# Altium schematic sheet impee-temp-book.SchDoc
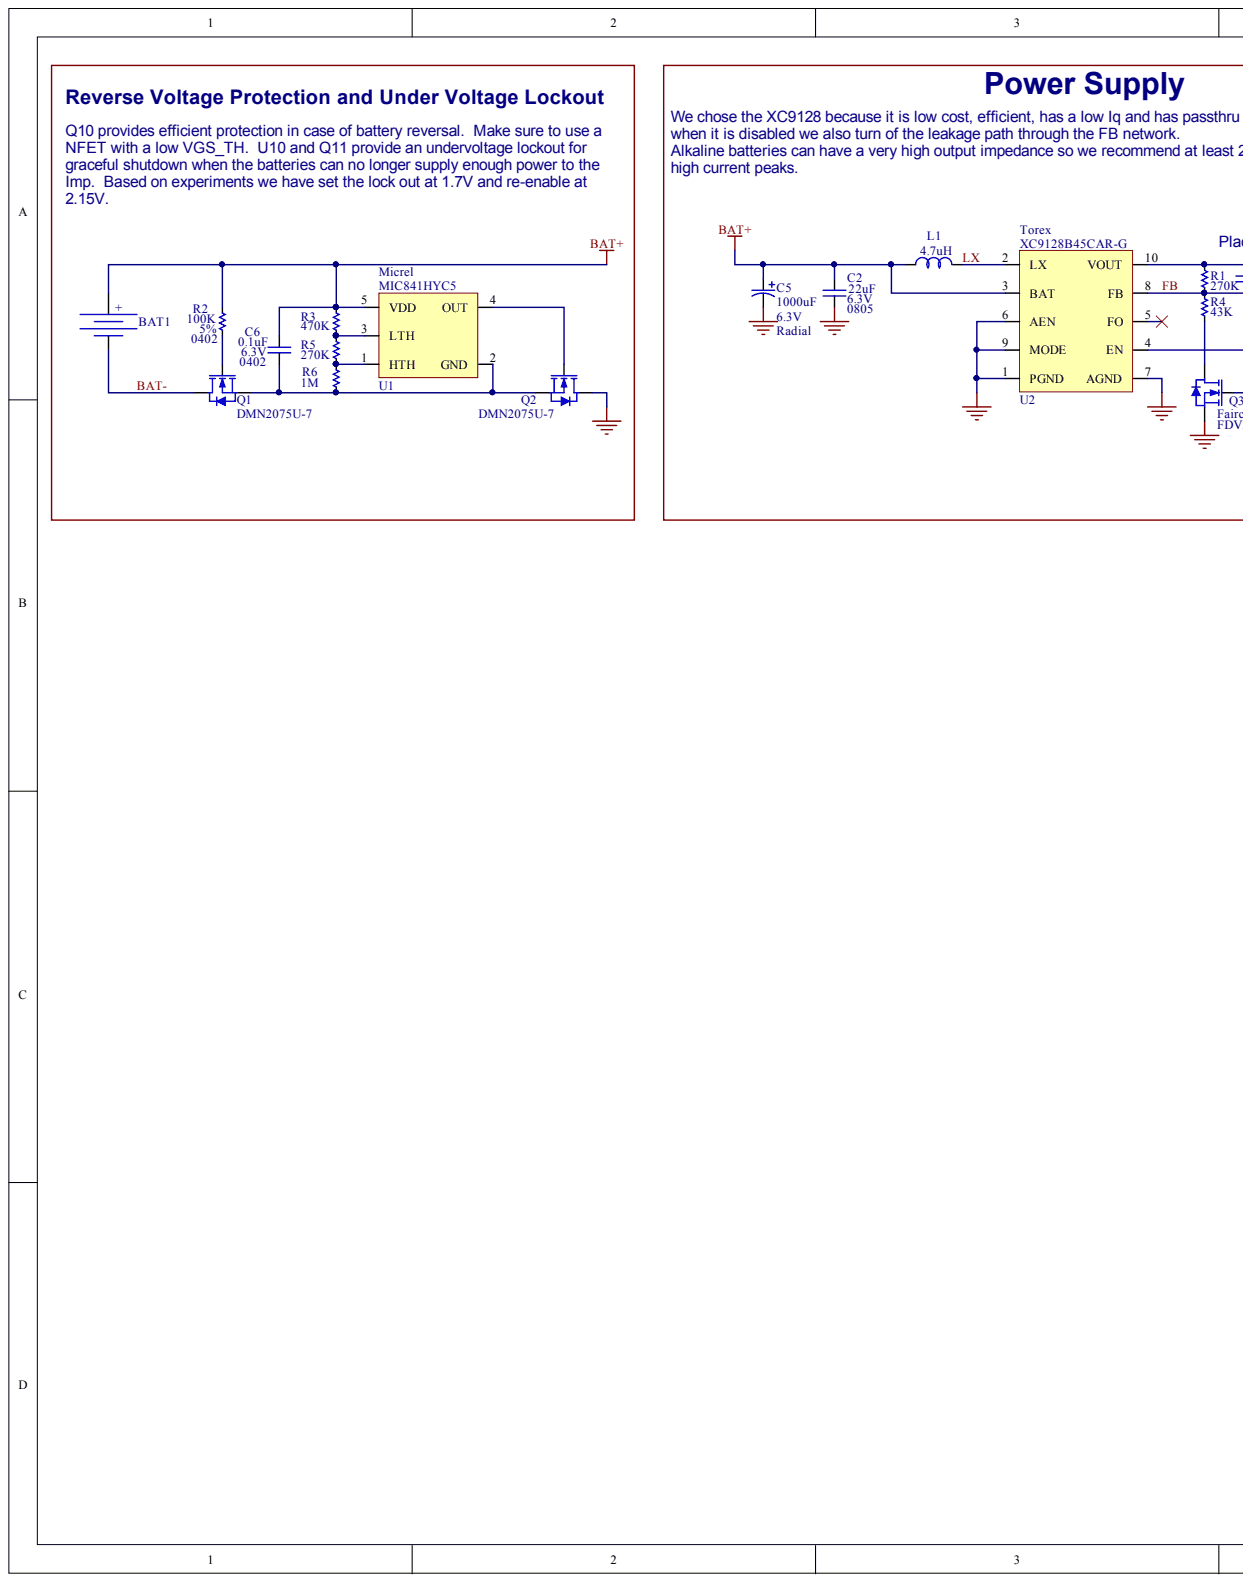
[diagram p0: impee-temp-book.SchDoc, left]
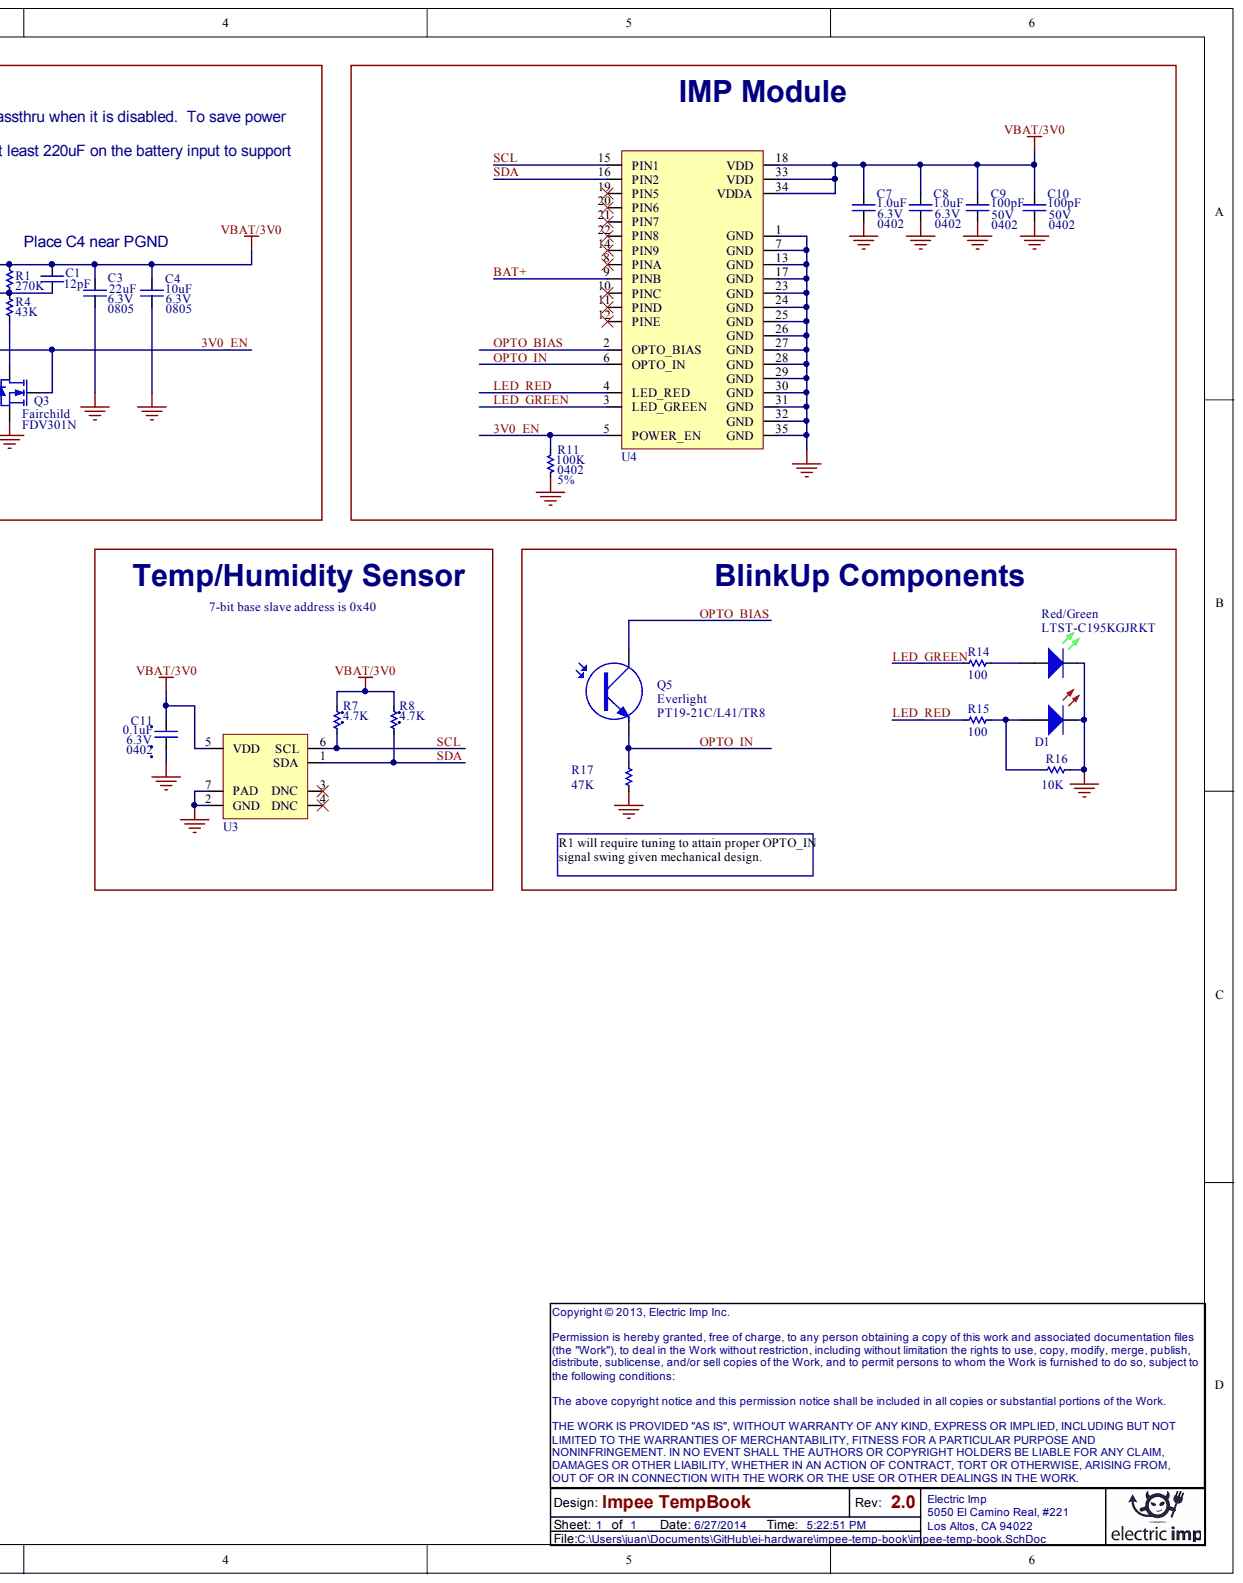
[diagram p1: impee-temp-book.SchDoc, right]

## Parts
BAT1  BATTERY  [12BH423-GR]  (value not drawn)
C1  value 12pF  [CAPC1005X07N]  (hidden comment: Ceramic Capacitor)
C2  value 22uF  Package: 0805  Voltage: 6.3V  [CAPC2012X14N]  (hidden comment: Ceramic Capacitor)
C3  value 22uF  Package: 0805  Voltage: 6.3V  [CAPC2012X14N]  (hidden comment: Ceramic Capacitor)
C4  value 10uF  Package: 0805  Voltage: 6.3V  [CAPC2012X14N]  (hidden comment: Ceramic Capacitor)
C5  value 1000uF  Package: Radial  Voltage: 6.3V  [CAPAE80X110-RADIAL-RIGHTANGLE]  (hidden comment: Polarized Capacitor)
C6  value 0.1uF  Package: 0402  Voltage: 6.3V  [CAPC1005X07N]  (hidden comment: Ceramic Capacitor)
C7  value 1.0uF  Package: 0402  Voltage: 6.3V  [CAPC1005X07N]  (hidden comment: Ceramic Capacitor)
C8  value 1.0uF  Package: 0402  Voltage: 6.3V  [CAPC1005X07N]  (hidden comment: Ceramic Capacitor)
C9  value 100pF  Package: 0402  Voltage: 50V  [CAPC1005X07N]  (hidden comment: Ceramic Capacitor)
C10  value 100pF  Package: 0402  Voltage: 50V  [CAPC1005X07N]  (hidden comment: Ceramic Capacitor)
C11  value 0.1uF  Package: 0402  Voltage: 6.3V  [CAPC1005X07N]  (hidden comment: Ceramic Capacitor)
D1  Color: Red/Green  Manufacturer Part Number: LTST-C195KGJRKT  [LED1616X07N-REDGREEN]  (hidden comment: LED Red and Green)
L1  value 4.7uH  [INDP6060X28N]  (hidden comment: Inductor)
Q1  Manufacturer Part Number: DMN2075U-7  [SOT95P300X130-3N]  (hidden comment: N-Channel MOSFET)
Q2  Manufacturer Part Number: DMN2075U-7  [SOT95P300X130-3N]  (hidden comment: N-Channel MOSFET)
Q3  Manufacturer: Fairchild  Manufacturer Part Number: FDV301N  [SOT95P300X130-3N]  (hidden comment: N-Channel MOSFET)
Q5  Manufacturer: Everlight  Manufacturer Part Number: PT19-21C/L41/TR8  [PHOTOTRANS1608X8]  (hidden comment: PHOTOTRANSISTOR)
R1  value 270K  [RESC1005X04N]  (hidden comment: Resistor)
R2  value 100K  Package: 0402  Tolerance: 5%  [RESC1005X04N]  (hidden comment: Resistor)
R3  value 470K  [RESC1005X04N]  (hidden comment: Resistor)
R4  value 43K  [RESC1005X04N]  (hidden comment: Resistor)
R5  value 270K  [RESC1005X04N]  (hidden comment: Resistor)
R6  value 1M  [RESC1005X04N]  (hidden comment: Resistor)
R7  value 4.7K  [RESC1005X04N]  (hidden comment: Resistor)
R8  value 4.7K  [RESC1005X04N]  (hidden comment: Resistor)
R11  value 100K  Package: 0402  Tolerance: 5%  [RESC1005X04N]  (hidden comment: Resistor)
R14  value 100  [RESC1005X04N]  (hidden comment: Resistor)
R15  value 100  [RESC1005X04N]  (hidden comment: Resistor)
R16  value 10K  [RESC1005X04N]  (hidden comment: Resistor)
R17  value 47K  [RESC1005X04N]  (hidden comment: Resistor)
U1  MIC841  Manufacturer: Micrel  Manufacturer Part Number: MIC841HYC5  [SOT65P200X125-5N]
U2  XC9128  Manufacturer: Torex  Manufacturer Part Number: XC9128B45CAR-G  [MSOP50P490X116-10N]
U3  SI7021  [Si7021]  (value not drawn)
U4  imp module  [IMP_MODULE]  (value not drawn)

## Nets
GND: C2.1 C3.1 C4.1 C5.2 C7.1 C8.1 C9.1 C10.1 C11.1 D1.KG D1.KR Q2.3 Q3.2 R11.1 R16.1 R17.1 U2.1(PGND) U2.6(AEN) U2.7(AGND) U2.9(MODE) U3.2(GND) U3.7(PAD) U4.1(GND) U4.7(GND) U4.13(GND) U4.17(GND) U4.23(GND) U4.24(GND) U4.25(GND) U4.26(GND) U4.27(GND) U4.28(GND) U4.29(GND) U4.30(GND) U4.31(GND) U4.32(GND) U4.35(GND)
VBAT/3V0: C1.2 C3.2 C4.2 C7.2 C8.2 C9.2 C10.2 C11.2 R1.2 R7.1 R8.1 U2.10(VOUT) U3.5(VDD) U4.18(VDD) U4.33(VDD) U4.34(VDDA)
3V0_EN: Q3.1 R11.2 U2.4(EN) U4.5(POWER_EN)
BAT+: BAT1.+ C2.2 C5.1 C6.2 L1.1 R2.2 R3.1 U1.5(VDD) U2.3(BAT) U4.9(PINB)
BAT-: BAT1.- Q1.3
FB: C1.1 R1.1 R4.2 U2.8(FB)
LED_GREEN: R14.2 U4.3(LED_GREEN)
LED_RED: R15.2 U4.4(LED_RED)
LX: L1.2 U2.2(LX)
OPTO_BIAS: Q5.C U4.2(OPTO_BIAS)
OPTO_IN: Q5.E R17.2 U4.6(OPTO_IN)
SCL: R7.2 U3.6(SCL) U4.15(PIN1)
SDA: R8.2 U3.1(SDA) U4.16(PIN2)
NetC6_1: C6.1 Q1.2 Q2.2 R6.1 U1.2(GND)
NetD1_AG: D1.AG R14.1
NetD1_AR: D1.AR R15.1 R16.2
NetQ1_1: Q1.1 R2.1
NetQ2_1: Q2.1 U1.4(OUT)
NetQ3_3: Q3.3 R4.1
NetR3_2: R3.2 R5.1 U1.3(LTH)
NetR5_2: R5.2 R6.2 U1.1(HTH)

Not connected: U2 5(FO); U3 3(DNC) 4(DNC); U4 8(PINA) 10(PINC) 11(PIND) 12(PINE) 14(PIN9) 19(PIN5) 20(PIN6) 21(PIN7) 22(PIN8)

## Library data of the parts
BAT1 = BAT00002: 2xAAA Series Battery Holder with Through-Hole PCB Mount; Manufacturer: Eagle Plastic Devices; Database Table Name: battery$; Voltage (Max): 3.2V; Voltage (Min): 1.6V; Voltage (Nominal): 3.0V; Component Kind: Standard; ComponentLink1URL: http://www.mouser.com/ds/2/209/EPD-200471-192655.pdf; Library Name: electromechanical.DbLib; Manufacturer Part Number: 12BH423-GR; Part Number: BAT00002; Supplier 1: Mouser; Supplier Part Number 1: 12BH423-GR; Supplier Price 1: 0.32
C1 = CAPC00041: CAP,C0G,12pF,5%,50V,0402#; Manufacturer: Yageo; Manufacturer Part Number: CC0402JRNPO9BN120; Database Table Name: capacitor$; Component Kind: Standard; Dielectric: C0G; Library Name: passives.DbLib; Manufacturer 2: Murata; Manufacturer Part Number 2: GRM1555C1H120JZ01D; Package: 0402; Part Number: CAPC00041; Supplier 1: Digi-Key; Supplier 2: Digi-Key; Supplier Part Number 1: 311-1016-1-ND; Supplier Part Number 2: 490-1279-1-ND; Supplier Price 1: 0.00324; Supplier Price 2: 0.00525; Tolerance: 5%; Voltage: 50V
C2 C3 = CAPC00039: CAP,X5R,22uF,10%,6.3V,0805,#; Manufacturer: TDK; Manufacturer Part Number: C2012X5R0J226K/1.25; Database Table Name: capacitor$; Component Kind: Standard; Dielectric: X5R; Library Name: passives.DbLib; Manufacturer 2: Taiyo Yuden; Manufacturer Part Number 2: JMK325BJ226KM-T; Part Number: CAPC00039; Stock Date: 3/12/2013; Stock Quantity: 1049; Supplier 1: Digi-Key; Supplier 2: Digi-Key; Supplier Part Number 1: 445-7679-1-ND; Supplier Part Number 2: 587-1471-2-ND; Supplier Price 1: 0.225; Supplier Price 2: 0.2288; Tolerance: 10%
C4 = CAPC00036: CAP,X5R,10uF,10%,6.3V,0805,#; Manufacturer: Yageo; Manufacturer Part Number: CC0805KKX5R5BB106; Database Table Name: capacitor$; Component Kind: Standard; Dielectric: X5R; Library Name: passives.DbLib; Manufacturer 2: Taiyo Yuden; Manufacturer Part Number 2: JMK212BJ106KD-T; Part Number: CAPC00036; Stock Date: 3/12/2013; Stock Quantity: 1000; Supplier 1: Digi-Key; Supplier 2: Digi-Key; Supplier Part Number 1: 311-1459-1-ND; Supplier Part Number 2: 587-1304-1-ND; Supplier Price 1: 0.03399; Supplier Price 2: 0.0408; Tolerance: 10%
C5 = CAPC00052: CAP,ALUM,1000uF,20%,6.3V, 8mm DIA,RADIAL#; Manufacturer: Kemet; Manufacturer Part Number: ESK108M6R3AG3AA; Database Table Name: capacitor$; Component Kind: Standard; Dielectric: Alum; Library Name: passives.DbLib; Part Number: CAPC00052; Stock Date: 3/12/2013; Stock Quantity: 50; Supplier 1: Digi-Key; Supplier 2: Mouser; Supplier Part Number 1: 399-6107-ND; Supplier Part Number 2: 80-ESK108M6R3AG3AA; Supplier Price 1: 0.07749; Supplier Price 2: 0.077; Tolerance: 20%
C6 C11 = CAPC00002: CAP,X5R,0.1uF,10%,6.3V, 0402,#; Manufacturer: TDK; Manufacturer Part Number: C1005X5R0J104K; Database Table Name: capacitor$; Component Kind: Standard; Dielectric: X5R; Library Name: passives.DbLib; Manufacturer 2: Murata; Manufacturer Part Number 2: GRM155R60J104KA01D; Part Number: CAPC00002; Stock Date: 4/19/2013; Stock Quantity: 300; Supplier 1: Digi-Key; Supplier 2: Mouser; Supplier Part Number 1: 445-1266-1-ND; Supplier Part Number 2: 81-GRM155R60J104KA1D; Supplier Price 1: 0.0066; Supplier Price 2: 0.007; Tolerance: 10%
C7 C8 = CAPC00005: CAP,X5R,1.0uF,10%,6.3V, 0402,#; Manufacturer: Murata; Manufacturer Part Number: GRM155R60J105KE19D; Database Table Name: capacitor$; Component Kind: Standard; Dielectric: X5R; Library Name: passives.DbLib; Manufacturer 2: Samsung; Manufacturer Part Number 2: CL05A105KQ5NNNC; Part Number: CAPC00005; Stock Date: 3/12/2013; Stock Quantity: 1000; Supplier 1: Digi-Key; Supplier 2: Digi-Key; Supplier Part Number 1: 490-1320-1-ND; Supplier Part Number 2: 1276-1010-1-ND; Supplier Price 1: 0.0087; Supplier Price 2: 0.009; Tolerance: 10%
C9 C10 = CAPC00043: CAP,C0G,100pF,5%,50V,0402; Manufacturer: Yageo; Manufacturer Part Number: CC0402JRNPO9BN101; Database Table Name: capacitor$; Component Kind: Standard; Dielectric: C0G; Library Name: passives.DbLib; Manufacturer 2: Samsung; Manufacturer Part Number 2: CL05C101JB5NNNC; Part Number: CAPC00043; Stock Date: 3/12/2013; Stock Quantity: 500; Supplier 1: Digi-Key; Supplier 2: Digi-Key; Supplier Part Number 1: 311-1024-1-ND; Supplier Part Number 2: 1276-1025-1-ND; Supplier Price 1: 0.00324; Supplier Price 2: 0.00324; Tolerance: 5%
D1 = LED00012: LED, Red and Green, 2/2V, 631/571nm, 25/35mcd, SMT; Manufacturer: Liteon; Current - Test: 20mA; Database Table Name: LED$; Lens Type: Clear; Millicandela Rating (min): 25mcd, 35mcd; Viewing Angle: 130°; Voltage - Forward (Vf) Typ: 2V, 2V; Wavelength - Dominant: 631nm, 571nm; Wavelength - Peak: 639nm, 574nm; Component Kind: Standard; ComponentLink1URL: http://media.digikey.com/pdf/Data%20Sheets/Lite-On%20PDFs/LTST-C195KGJRKT.pdf; Library Name: opto.DbLib; Package: SMT; Part Number: LED00012; Stock Date: 2/27/2013; Stock Quantity: 4; Supplier 1: Digi-Key; Supplier Part Number 1: 160-1452-1-ND; Supplier Price 1: 0.1206
L1 = IND00007: IND, 4.7uH, 20%, 2.7A Isat, 403 mOhm, 6028; Manufacturer: Taiyo Yuden; Manufacturer Part Number: NR6028T4R7M; Database Table Name: inductor$; Component Kind: Standard; ComponentLink1URL: http://www.yuden.co.jp/productdata/catalog/en/wound04_e.pdf; Current: 2.7A; Current - Temperature Rise: 2.7A; DC Resistance (DCR): 403 mOhm; Library Name: passives.DbLib; Package: 6028; Part Number: IND00007; Stock Date: 3/6/2013; Stock Quantity: 31; Supplier 1: Digi-Key; Supplier Part Number 1: 587-2100-1-ND; Supplier Price 1: 0.15525; Tolerance: 20%
Q1 Q2 = NFET00004: NFET, 20V, 4.2A, SOT23; Manufacturer: Diodes Incorporated; Database Table Name: NFET$; Power - Max: 800mW; Rds On (Max) @ Id, Vgs: 45 mOhm @ 3.1A, 2.5V; Vgs(th) (Max) @ Id: 1.0V @ 250uA; Component Kind: Standard; ComponentLink1URL: http://www.diodes.com/datasheets/ds31837.pdf; Current - Continuous Drain (Id) @ 25° C: 4.2A; Drain to Source Voltage (Vdss): 20V; Library Name: discretes.DbLib; Package: SOT23; Part Number: NFET00004; Stock Date: 3/5/2013; Stock Quantity: 41; Supplier 1: Digi-Key; Supplier Part Number 1: DMN2075U-7DICT-ND; Supplier Price 1: 0.08295
Q3 = NFET00003: NFET, 25V, 220mA, SOT23; Database Table Name: NFET$; Power - Max: 350mW; Rds On (Max) @ Id, Vgs: 5 ohm @ 200mA, 2.7V; Vgs(th) (Max) @ Id: 1.06V @ 250uA; Component Kind: Standard; ComponentLink1URL: http://www.fairchildsemi.com/ds/FD/FDV301N.pdf; Current - Continuous Drain (Id) @ 25° C: 220mA; Drain to Source Voltage (Vdss): 25V; Library Name: discretes.DbLib; Package: SOT23; Part Number: NFET00003; Stock Date: 3/5/2013; Supplier 1: Digi-key; Supplier Part Number 1: FDV301NCT-ND; Supplier Price 1: 0.04155
Q5 = PHOTO00011: Phototrans, Clear, Top View, 940nm, 0.4V, 0.6mA, 0603; Database Table Name: Phototransistor$; Component Kind: Standard; ComponentLink1URL: http://www.digikey.com/product-detail/en/PT19-21C%2FL41%2FTR8/1080-1384-1-ND/2676118; Lens Type: Clear; Library Name: opto.DbLib; Package: 0603; Part Number: PHOTO00011; Stock Date: 7/15/2013; Stock Quantity: 10; Supplier 1: Digi-Key; Supplier Part Number 1: 1080-1384-1-ND; Supplier Price 1: 0.07101
R1 = RES00040: RES,270K,1%,0.063W,0402; Manufacturer: Yageo; Manufacturer Part Number: RC0402FR-07270KL; Database Table Name: resistor$; Component Kind: Standard; Library Name: passives.DbLib; Manufacturer 2: Rohm; Manufacturer Part Number 2: MCR01MZPF2703; Package: 0402; Part Number: RES00040; Power: 0.063W; Supplier 1: Digi-Key; Supplier 2: Digi-Key; Supplier Part Number 1: 311-270KLRCT-ND; Supplier Part Number 2: RHM270KLCT-ND; Supplier Price 1: 0.0027; Supplier Price 2: 0.00544; Tolerance: 1%
R2 R11 = RES00001: RES,100k,5%,0.063W,0402,#; Manufacturer: Samsung; Manufacturer Part Number: RC1005J104CS; Database Table Name: resistor$; Component Kind: Standard; Library Name: passives.DbLib; Manufacturer 2: ROHM; Manufacturer Part Number 2: MCR01MRTJ104; Part Number: RES00001; Power: 0.063W; Stock Date: 4/5/2013; Stock Quantity: 2100; Supplier 1: Digi-Key; Supplier 2: Digi-Key; Supplier Part Number 1: 1276-4424-1-ND; Supplier Part Number 2: HM100KCECT-ND; Supplier Price 1: 0.0023; Supplier Price 2: 0.00233
R3 = RES00009: RES,470k,5%,0.063W,0402; Manufacturer: Yageo; Manufacturer Part Number: RC0402JR-07470KL; Database Table Name: resistor$; Component Kind: Standard; Library Name: passives.DbLib; Manufacturer 2: ROHM; Manufacturer Part Number 2: MCR01MRTJ474; Package: 0402; Part Number: RES00009; Power: 0.063W; Supplier 1: Digi-Key; Supplier 2: Digi-Key; Supplier Part Number 1: 311-470KJRCT-ND; Supplier Part Number 2: RHM470KCECT-ND; Supplier Price 1: 0.00237; Supplier Price 2: 0.00352; Tolerance: 5%
R4 = RES00041: RES,43K,1%,0.063W,0402; Manufacturer: Yageo; Manufacturer Part Number: RC0402FR-0743KL; Database Table Name: resistor$; Component Kind: Standard; Library Name: passives.DbLib; Manufacturer 2: Rohm; Manufacturer Part Number 2: MCR01MZPF4302; Package: 0402; Part Number: RES00041; Power: 0.063W; Stock Date: 4/5/2013; Stock Quantity: 10; Supplier 1: Digi-Key; Supplier 2: Digi-Key; Supplier Part Number 1: 311-43.0KLRCT-ND; Supplier Part Number 2: RHM43.0KLCT-ND; Supplier Price 1: 0.0027; Supplier Price 2: 0.00544; Tolerance: 1%
R5 = RES00015: RES,270K,5%,0.063W,0402; Manufacturer: Yageo; Manufacturer Part Number: RC0402JR-07270KL; Database Table Name: resistor$; Component Kind: Standard; Library Name: passives.DbLib; Manufacturer 2: ROHM; Manufacturer Part Number 2: MCR01MZPJ274; Package: 0402; Part Number: RES00015; Power: 0.063W; Stock Date: 4/5/2013; Stock Quantity: 6; Supplier 1: Digi-Key; Supplier 2: Digi-Key; Supplier Part Number 1: 311-270KJRDKR-ND; Supplier Part Number 2: RHM270KJCT-ND; Supplier Price 1: 0.00237; Supplier Price 2: 0.00384; Tolerance: 5%
R6 = RES00010: RES,1M,5%,0.063W,0402,#; Manufacturer: Yageo; Manufacturer Part Number: RC0402JR-071ML; Database Table Name: resistor$; Component Kind: Standard; Library Name: passives.DbLib; Manufacturer 2: ROHM; Manufacturer Part Number 2: MCR01MRTJ105; Package: 0402; Part Number: RES00010; Power: 0.063W; Stock Date: 4/5/2013; Stock Quantity: 13; Supplier 1: Digi-Key; Supplier 2: Digi-Key; Supplier Part Number 1: 311-1.0MJRCT-ND; Supplier Part Number 2: RHM1.0MCECT-ND; Supplier Price 1: 0.00237; Supplier Price 2: 0.00352; Tolerance: 5%
R7 R8 = RES00038: RES,4.7K,1%,0.1W,0402,#; Manufacturer: Yageo; Manufacturer Part Number: RC0402FR-134K7L; Database Table Name: resistor$; Power: 0.063W; Component Kind: Standard; Library Name: passives.DbLib; Manufacturer 2: Stackpole; Manufacturer Part Number 2: RMCF0402FT4K70; Part Number: RES00038; Stock Date: 4/5/2013; Stock Quantity: 1000; Supplier 1: Digi-Key; Supplier 2: Digi-Key; Supplier Part Number 1: 311-4.7KPFCT-ND; Supplier Part Number 2: RMCF0402FT4K70CT-ND; Supplier Price 1: 0.0027; Supplier Price 2: 0.00384
R14 R15 = RES00024: RES,100 Ohm,1%,0.063W,0402,#; Manufacturer: Yageo; Manufacturer Part Number: RC0402FR-07100RL; BOMOPTION: DNS; Database Table Name: resistor$; Component Kind: Standard; Library Name: passives.DbLib; Manufacturer 2: ROHM; Manufacturer Part Number 2: MCR01MRTF1000; Package: 0402; Part Number: RES00024; Power: 0.063W; Supplier 1: Digi-Key; Supplier 2: Digi-Key; Supplier Part Number 1: 311-100LRCT-ND; Supplier Part Number 2: RHM100CDCT-ND; Supplier Price 1: 0.0027; Supplier Price 2: 0.0048; Tolerance: 1%
R16 = RES00006: RES,10k,5%,0.063W,0402,#; Manufacturer: Stackpole; Manufacturer Part Number: RMCF0402JT10K0; Database Table Name: resistor$; Component Kind: Standard; Library Name: passives.DbLib; Manufacturer 2: ROHM; Manufacturer Part Number 2: MCR01MRTJ103; Package: 0402; Part Number: RES00006; Power: 0.063W; Stock Date: 4/19/2013; Stock Quantity: 120; Supplier 1: Digi-Key; Supplier 2: Digi-Key; Supplier Part Number 1: RMCF0402JT10K0CT-ND; Supplier Part Number 2: RHM10KCECT-ND; Supplier Price 1: 0.00256; Supplier Price 2: 0.00352; Tolerance: 5%
R17 = RES00008: RES,47k,5%,0.063W,0402; Manufacturer: Yageo; Manufacturer Part Number: RC0402JR-0747KL; BOMOPTION: DNS; Database Table Name: resistor$; Component Kind: Standard; Library Name: passives.DbLib; Manufacturer 2: ROHM; Manufacturer Part Number 2: MCR01MRTJ473; Package: 0402; Part Number: RES00008; Power: 0.063W; Supplier 1: Digi-Key; Supplier 2: Digi-Key; Supplier Part Number 1: 311-47KJRCT-ND; Supplier Part Number 2: RHM47KCECT-ND; Supplier Price 1: 0.00237; Supplier Price 2: 0.00352; Tolerance: 5%
U1 = IC00032: IC, Comparator with Reference and Programmable Hysteresis, SOT-353; Database Table Name: misc$; Component Kind: Standard; ComponentLink1URL: http://www.micrel.com/_PDF/mic841.pdf; Library Name: ic.DbLib; Part Number: IC00032; Stock Date: 2/27/2013; Stock Quantity: 1; Supplier 1: Digi-Key; Supplier Part Number 1: 576-2923-1-ND; Supplier Price 1: 0.504
U2 = IC00026: IC, DC/DC boost,Adj Output,6Vin,500mA,MSOP10; Database Table Name: misc$; Component Kind: Standard; ComponentLink1URL: http://www.torex.co.jp/english/products/dcdc_converters/data/XC9128~29.pdf; Library Name: ic.DbLib; Part Number: IC00026; Stock Date: 2/27/2013; Stock Quantity: 8
U3 = IC00157: IC, Sensor, Temp+Humidity, Si7021, DFN6; Manufacturer: Silicon Labs; Database Table Name: misc$; Component Kind: Standard; Library Name: ic.DbLib; Manufacturer Part Number: Si7021; Part Number: IC00157
U4 = MOD00002: Module, Electric Imp Solder Down with Antenna; Manufacturer Part Number: IMP002; Database Table Name: misc$; Component Kind: Standard; Library Name: modules.DbLib; Manufacturer: Electric Imp; Part Number: MOD00002
